# Altium schematic sheet Hierarchal.SchDoc
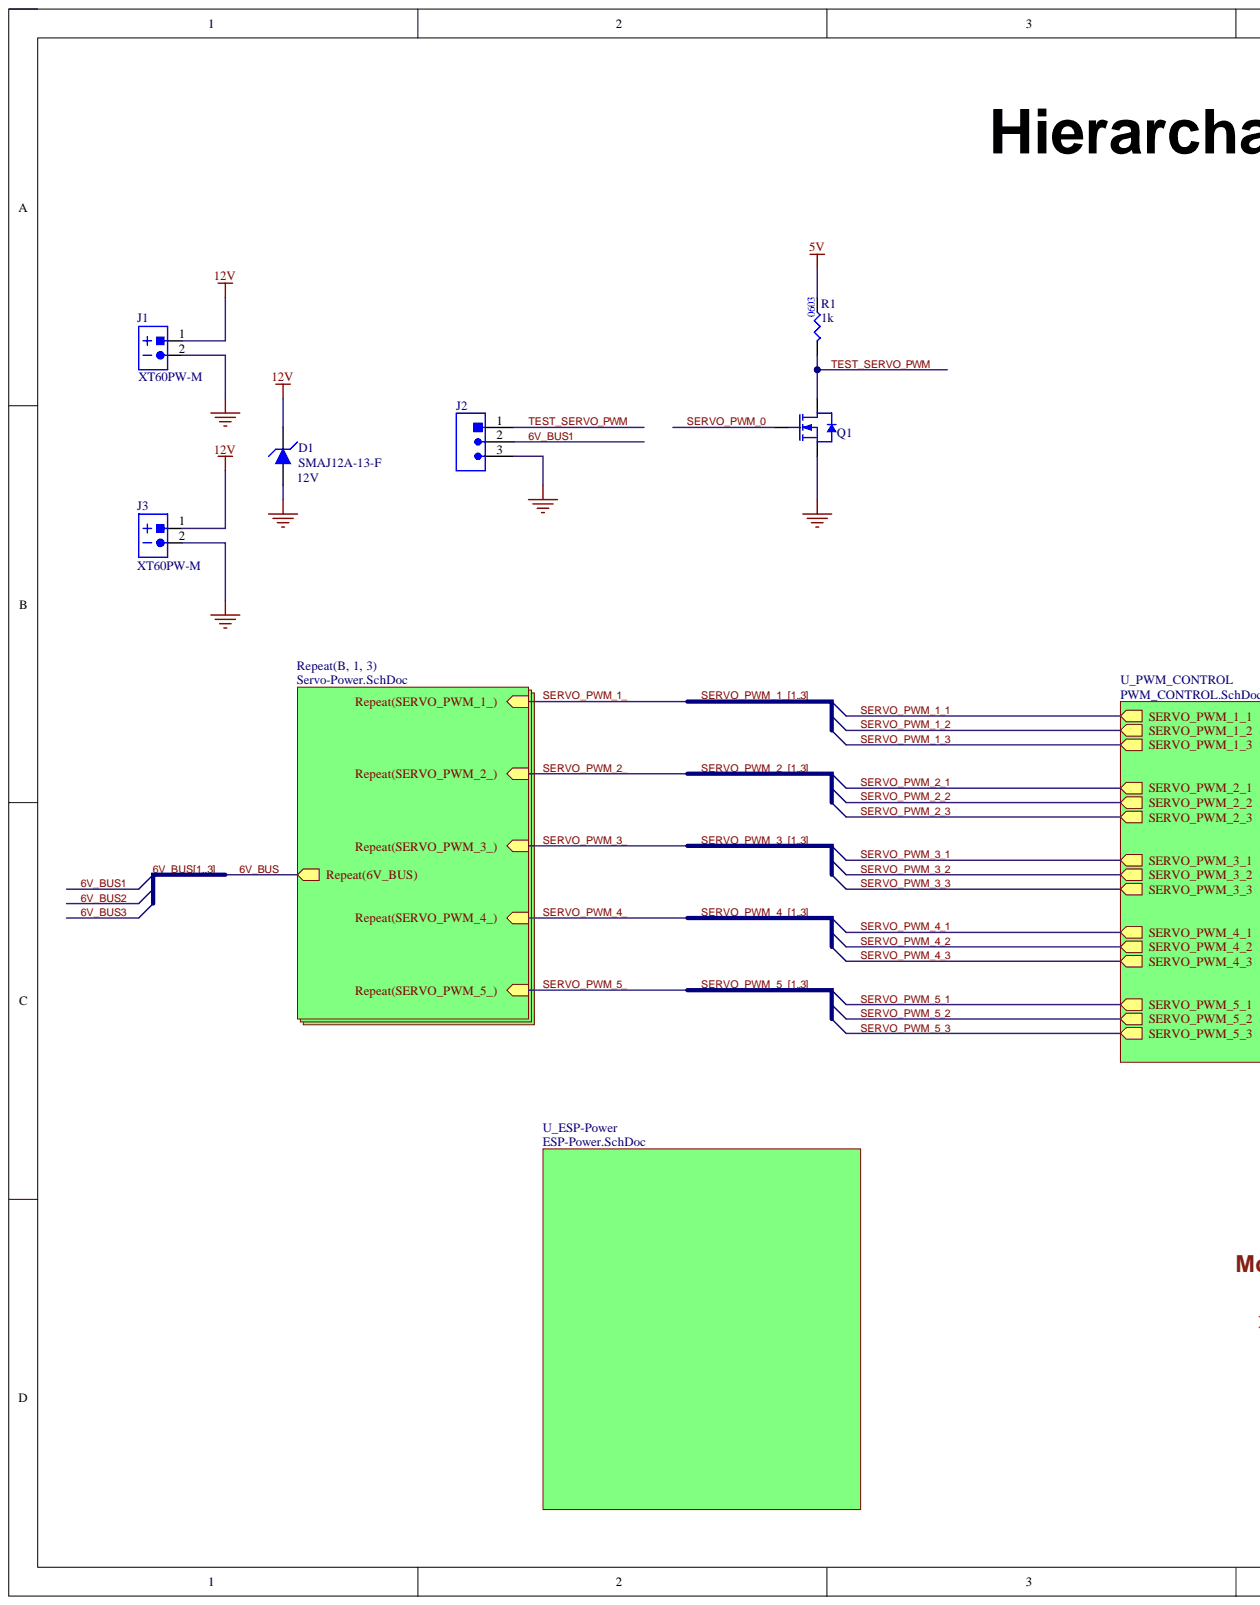
[diagram p0: Hierarchal.SchDoc, left]
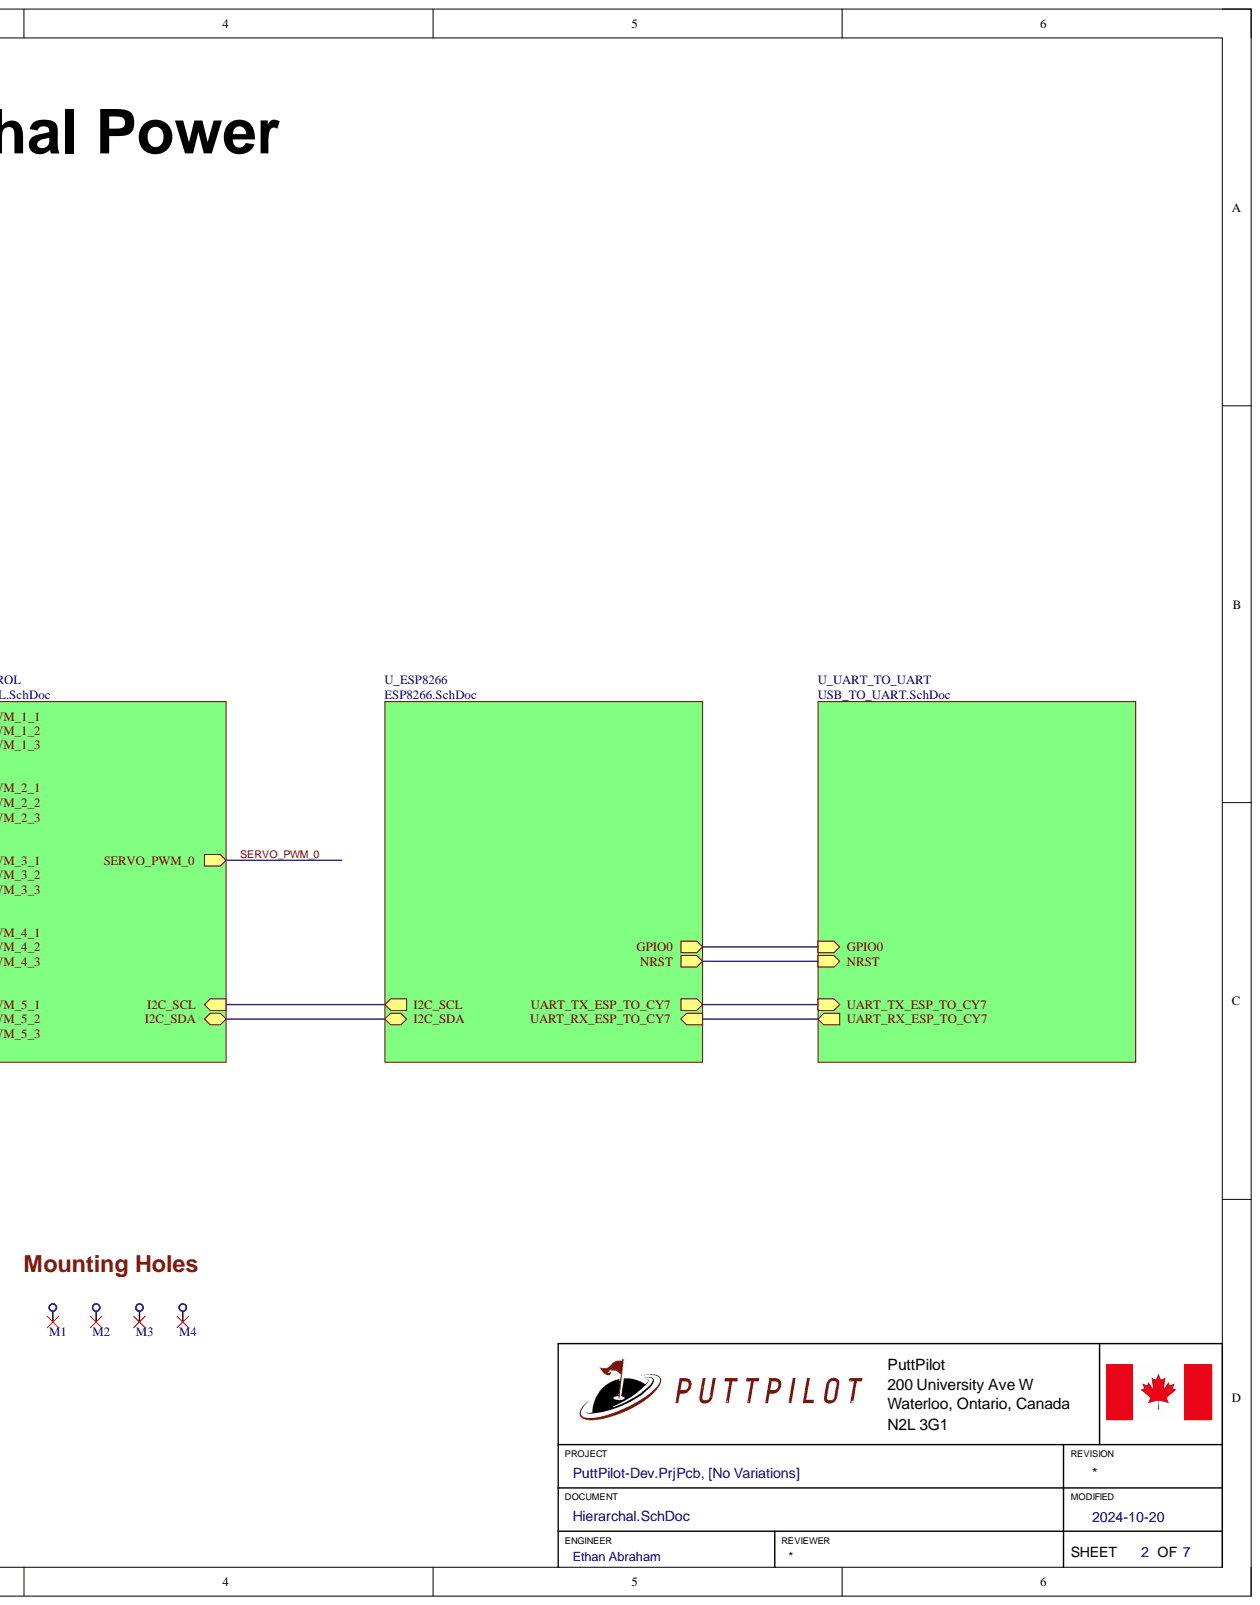
[diagram p1: Hierarchal.SchDoc, right]

## Parts
D1  SMAJ12A-13-F  Rep Pk Reverse Voltage-Max: 12V  [SMAJ12A-13-F]
J1  XT60PW-M  [XT60PW-M]
J2  TSW-101-08-G-T-RA  [HEADER_3POS_R/A_2.54MM]  (value not drawn)
J3  XT60PW-M  [XT60PW-M]
M1  .HOLE_M3  [.HOLE_M3]  (value not drawn)
M2  .HOLE_M3  [.HOLE_M3]  (value not drawn)
M3  .HOLE_M3  [.HOLE_M3]  (value not drawn)
M4  .HOLE_M3  [.HOLE_M3]  (value not drawn)
Q1  DMN6140L-7  [.SOT-23-3]  (value not drawn)
R1  Resistance: 1k  Size Code: 0603  [.RES_0603]  (hidden comment: RC0603FR-071KL)

## Nets
5V: R1.2
12V: D1.2 J1.1 J3.1
GND: D1.1 J1.2 J2.3 J3.2 Q1.2
6V_BUS1: J2.2  [also labelled 6V_BUS]
SERVO_PWM_0: Q1.1
TEST_SERVO_PWM: J2.1 Q1.3 R1.1
NetM1_1: M1.1
NetM2_1: M2.1
NetM3_1: M3.1
NetM4_1: M4.1
6V_BUS2:  [also labelled 6V_BUS]
6V_BUS3:  [also labelled 6V_BUS]
GPIO0:
I2C_SCL:
I2C_SDA:
NRST:
SERVO_PWM_1_1:  [also labelled SERVO_PWM_1_]
SERVO_PWM_1_2:  [also labelled SERVO_PWM_1_]
SERVO_PWM_1_3:  [also labelled SERVO_PWM_1_]
SERVO_PWM_2_1:  [also labelled SERVO_PWM_2_]
SERVO_PWM_2_2:  [also labelled SERVO_PWM_2_]
SERVO_PWM_2_3:  [also labelled SERVO_PWM_2_]
SERVO_PWM_3_1:  [also labelled SERVO_PWM_3_]
SERVO_PWM_3_2:  [also labelled SERVO_PWM_3_]
SERVO_PWM_3_3:  [also labelled SERVO_PWM_3_]
SERVO_PWM_4_1:  [also labelled SERVO_PWM_4_]
SERVO_PWM_4_2:  [also labelled SERVO_PWM_4_]
SERVO_PWM_4_3:  [also labelled SERVO_PWM_4_]
SERVO_PWM_5_1:  [also labelled SERVO_PWM_5_]
SERVO_PWM_5_2:  [also labelled SERVO_PWM_5_]
SERVO_PWM_5_3:  [also labelled SERVO_PWM_5_]
UART_RX_ESP_TO_CY7:
UART_TX_ESP_TO_CY7:

## Library data of the parts
D1 = SMAJ12A-13-F: TVS DIODE 12VWM; Manufacturer: Diodes; Additional Feature: EXCELLENT CLAMPING CAPABILITY; Breakdown Voltage-Nom: 14V; Clamping Voltage-Max: 19.9V; Diode Element Material: SILICON; Diode Type: TRANS VOLTAGE SUPPRESSOR; Max Breakdown Voltage: 14.7V; Max Power Dissipation: 1W; Min Breakdown Voltage: 13.3V; Non-rep Peak Rev Power Dis-Max: 400W; Number of Elements: 1; Package Body Material: Plastic; Polarity: Unidirectional; Qualification Status: Not Qualified; Time @ Peak Reflow Temperature-Max (s): 40s; Category: TVS Diodes; Component Kind: Standard; ComponentLink1Description: https://datasheet.ciiva.com:443/pdfs/VipMasterIC/IC/DIOD/DIOD-S-A0009689838/DIOD-S-A0009691421-1.pdf?src-supplier=IHS+Markit; ComponentLink1URL: https://datasheet.ciiva.com:443/pdfs/VipMasterIC/IC/DIOD/DIOD-S-A0009689838/DIOD-S-A0009691421-1.pdf?src-supplier=IHS+Markit; ComponentLink2Description: https://datasheet.ciiva.com/15143/getdatasheetpartid-164236-15143864.pdf?src-supplier=Verical; ComponentLink2URL: https://datasheet.ciiva.com/15143/getdatasheetpartid-164236-15143864.pdf?src-supplier=Verical; JESD-30 Code: R-PDSO-C2; JESD-609 Code: e3; Manufacturer Part Number: SMAJ12A-13-F; Max Operating Temperature: 150°C; Min Operating Temperature: -55°C; Moisture Sensitivity Level: 1; Number of Pins: 2; Package Shape: Rectangular; Package Style: SMALL OUTLINEMeter; Peak Reflow Temperature (Cel): 260°C; Pricing 1: 1=0.29, 10=0.197, 100=0.1322, 500=0.1019, 1000=0.09178, 2000=0.08313 (USD); Reach SVHC Compliant: No; RoHS: Yes; RoHS Compliant: Yes; Stock 1: 25706; Supplier 1: Digikey; Supplier Part Number 1: SMAJ12A-FDICT-ND; Surface Mount: Yes; Technology: AVALANCHE; Terminal Finish: Tin; Terminal Form: C BEND; Terminal Position: DUAL; Library Name: PuttPilot.SchLib
J1 J3 = XT60PW-M: XT60PW Male; Manufacturer: Unclassified; Component Kind: Standard; Manufacturer Part Number: XT60PW-M; Supplier Part Number 1: 1805375; Library Name: PuttPilot.SchLib
J2 = TSW-101-08-G-T-RA: CONN HEADER R/A 3POS 2.54MM; Component Kind: Standard; Library Name: PuttPilot.SchLib
M1-M4 = .HOLE_M3: .HOLE M3; Component Kind: Standard; Library Name: PuttPilot.SchLib
Q1 = DMN6140L-7: MOSFET N-CH 60V 1.6A SOT-23; Manufacturer: Diodes; Drain to Source Resistance: 140mR; Fall Time: 2.7ns; Gate to Source Voltage (Vgs): 20V; Input Capacitance: 315pF; Max Power Dissipation: 700mW; Number of Channels: 1; Polarity: N-CHANNEL; Rise Time: 3.6ns; Threshold Voltage: 3V; Turn-Off Delay Time: 16.3ns; Turn-On Delay Time: 2.6ns; Case/Package: SOT-23; Category: MOSFETs; Component Kind: Standard; ComponentLink1Description: https://datasheet.ciiva.com/29747/dmn6140l-29747654.pdf?src-supplier=Digikey; ComponentLink1URL: https://datasheet.ciiva.com/29747/dmn6140l-29747654.pdf?src-supplier=Digikey; ComponentLink2Description: https://datasheet.ciiva.com/29747/dmn6140l-29747660.pdf?src-supplier=Digikey; ComponentLink2URL: https://datasheet.ciiva.com/29747/dmn6140l-29747660.pdf?src-supplier=Digikey; Continuous Drain Current (ID): 1.6A; Drain to Source Voltage (Vdss): 60V; Lead Free: Lead Free; Manufacturer Part Number: DMN6140L-7; Max Operating Temperature: 150°C; Min Operating Temperature: -55°C; Mount: Surface Mount; Number of Pins: 3; Package Quantity: 1; Packaging: Tape and Reel; Pricing 1: 3000=0.0489, 9000=0.047, 15000=0.0462, 45000=0.0445, 75000=0.043 (USD); Rds On Max: 140mR; Reach SVHC Compliant: No; RoHS: Yes; RoHS Compliant: Yes; Series: -; Stock 1: 624000; Supplier 1: Future Electronics; Supplier Part Number 1: 4046633; Weight: 0.000282oz; Library Name: PuttPilot.SchLib
R1 = RC0603FR-071KL: RES 1K OHM 0603; Manufacturer: Yageo; Additional Feature: LASER TRIMMABLE; Package Height: 0.45mm; Package Length: 1.6mm; Package Width: 0.8mm; Rated Power Dissipation (P): 100mW; Rated Temperature: 70°C; Temperature Coefficient: 100ppm/°C; Tolerance: 1%; Working Voltage: 75V; Category: Chip SMD Resistors; Component Kind: Standard; ComponentLink1Description: https://datasheet.ciiva.com:443/pdfs/VipMasterIC/IC/YAGO/YAGO-S-A0008145938/YAGO-S-A0008145938-1.pdf?src-supplier=IHS+Markit; ComponentLink1URL: https://datasheet.ciiva.com:443/pdfs/VipMasterIC/IC/YAGO/YAGO-S-A0008145938/YAGO-S-A0008145938-1.pdf?src-supplier=IHS+Markit; ComponentLink2Description: https://datasheet.ciiva.com/11808/0900766b80f96399-11808081.pdf?src-supplier=Rs; ComponentLink2URL: https://datasheet.ciiva.com/11808/0900766b80f96399-11808081.pdf?src-supplier=Rs; ComponentLink3Description: https://datasheet.ciiva.com/8896/pyu-rc0603-51-rohs-l-4-8896106.pdf?src-supplier=Avnet; ComponentLink3URL: https://datasheet.ciiva.com/8896/pyu-rc0603-51-rohs-l-4-8896106.pdf?src-supplier=Avnet; ComponentLink4Description: https://datasheet.ciiva.com/7660/1868787-7660790.pdf?src-supplier=Element14; ComponentLink4URL: https://datasheet.ciiva.com/7660/1868787-7660790.pdf?src-supplier=Element14; ComponentLink5Description: https://datasheet.ciiva.com/7746/1738695-7746279.pdf?src-supplier=Element14; ComponentLink5URL: https://datasheet.ciiva.com/7746/1738695-7746279.pdf?src-supplier=Element14; ComponentLink6Description: https://datasheet.ciiva.com/7746/1686128-7746280.pdf?src-supplier=Element14; ComponentLink6URL: https://datasheet.ciiva.com/7746/1686128-7746280.pdf?src-supplier=Element14; ComponentLink7Description: https://datasheet.ciiva.com/7746/1738695-7746281.pdf?src-supplier=Element14; ComponentLink7URL: https://datasheet.ciiva.com/7746/1738695-7746281.pdf?src-supplier=Element14; ComponentLink8Description: https://datasheet.ciiva.com/7983/443971-7983613.pdf?src-supplier=Element14; ComponentLink8URL: https://datasheet.ciiva.com/7983/443971-7983613.pdf?src-supplier=Element14; ComponentLink9Description: https://datasheet.ciiva.com/15189/getdatasheetpartid-404327-15189644.pdf?src-supplier=Verical; ComponentLink9URL: https://datasheet.ciiva.com/15189/getdatasheetpartid-404327-15189644.pdf?src-supplier=Verical; Construction: Chip; JESD-609 Code: e3; Manufacturer Part Number: RC0603FR-071KL; Max Operating Temperature: 155°C; Min Operating Temperature: -55°C; Mount: Surface Mount; Number of Pins: 2; Package Shape: Rectangular; Package Style: SMTMeter; Packaging: Tape and Reel; Pricing 1: 5000=0.0026, 50000=0.0025, 150000=0.0024, 375000=0.0023, 1000000=0.0022 (USD); Reach SVHC Compliant: Yes; Resistor Type: FIXED RESISTOR; RoHS: Yes; RoHS Compliant: Yes; Stock 1: 12810000; Supplier 1: Future Electronics; Supplier Part Number 1: 4016291; Technology: METAL GLAZE/THICK FILM; Terminal Finish: Nickel; Termination: Wraparound; Library Name: PuttPilot.SchLib
